# Altium schematic sheet Schematic.SchDoc(Schematic)
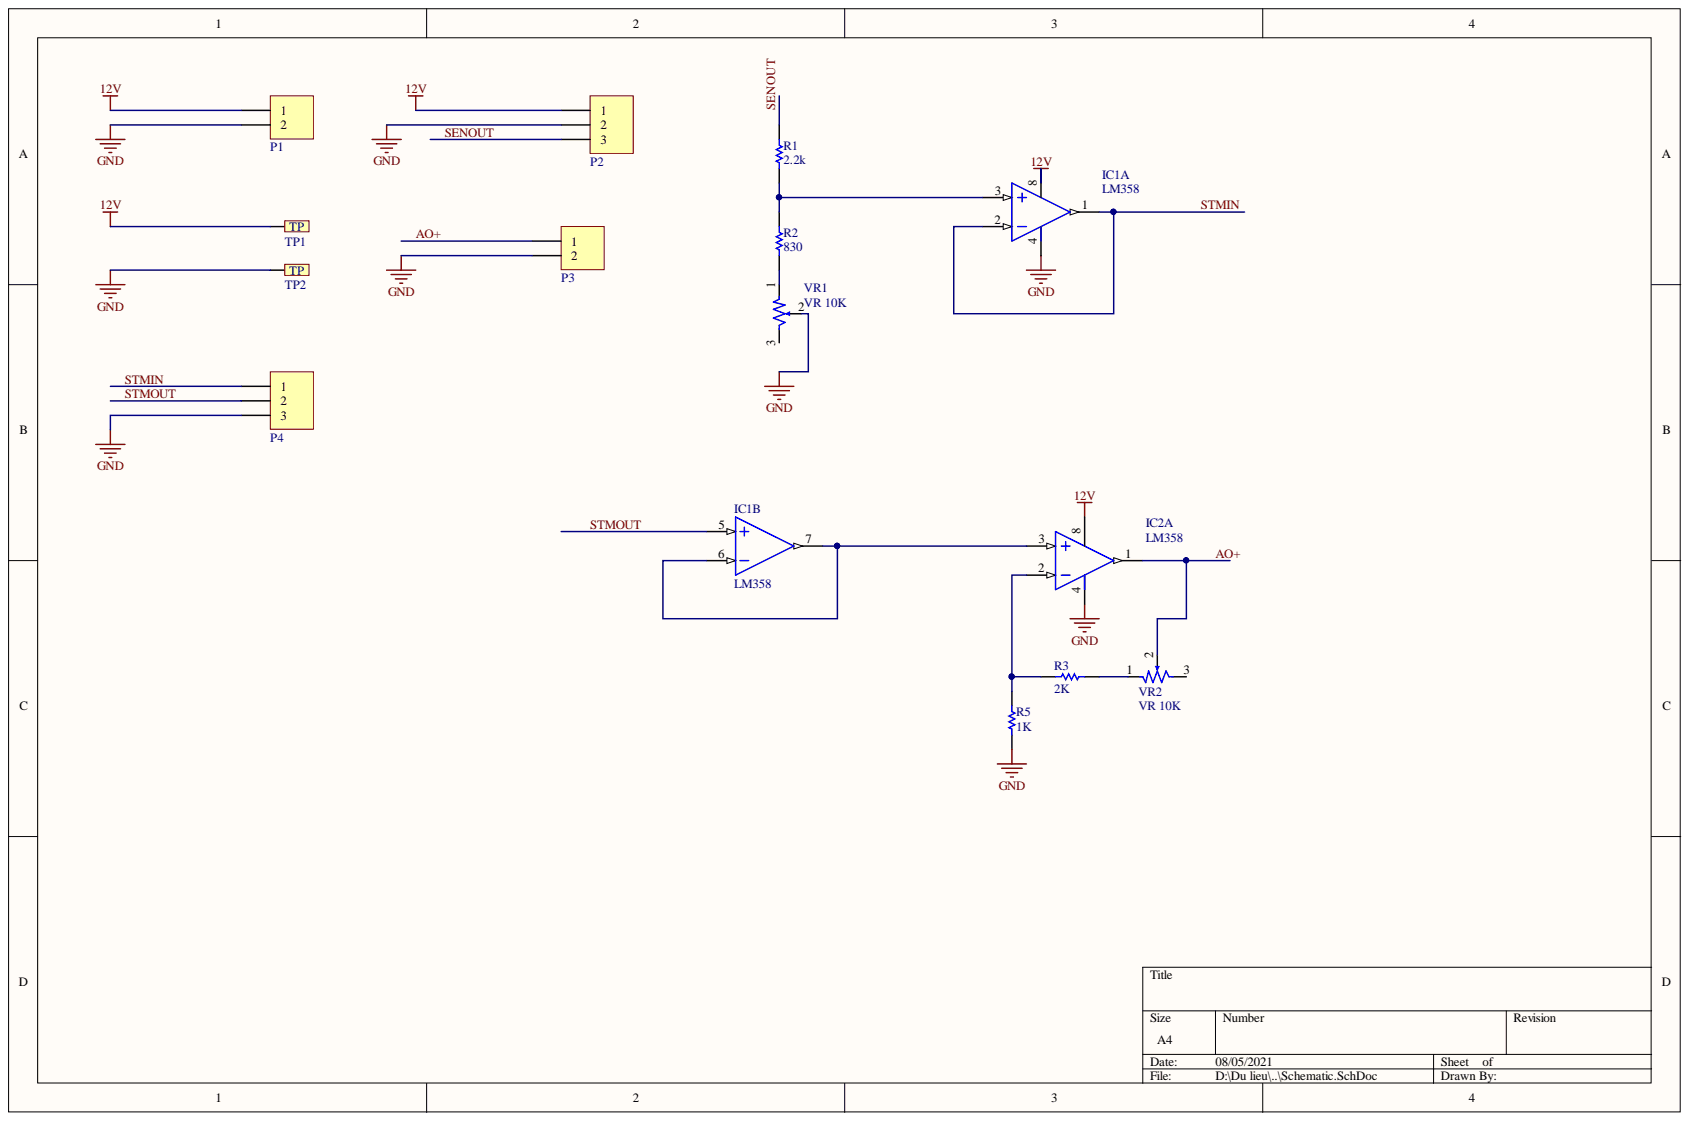
[diagram p0: Schematic.SchDoc]

## Parts
IC1  LM358  [DIP8]  (parts A,B)
IC2  LM358  [DIP8]  (part A)
P1  Header 2H  [JP2H]  (value not drawn)
P2  Header 3  [JP3]  (value not drawn)
P3  Header 2H  [JP2H]  (value not drawn)
P4  Header 3  [JP3]  (value not drawn)
R1  value 2.2k  [RES-SM-0805]  (hidden comment: RESISTOR SMD)
R2  value 830  [RES-SM-0805]  (hidden comment: RESISTOR SMD)
R3  value 2K  [RES-SM-0805]  (hidden comment: RESISTOR SMD)
R5  value 1K  [RES-SM-0805]  (hidden comment: RESISTOR SMD)
TP1  Test Point  [TP]  (value not drawn)
TP2  Test Point  [TP]  (value not drawn)
VR1  10K  value VR 10K  [Varistor 1]
VR2  10K  value VR 10K  [Varistor 1]

## Nets
12V: IC1.8 IC2.8 P1.1 P2.1 TP1.TP1
GND: IC1.4 IC2.4 P1.2 P2.2 P3.2 P4.3 R5.2 TP2.TP1 VR1.2
AO+: IC2.1 P3.1 VR2.2
SENOUT: P2.3 R1.2
STMIN: IC1.1 IC1.2 P4.1
STMOUT: IC1.5 P4.2
NetIC1_3: IC1.3 R1.1 R2.2
NetIC1_6: IC1.6 IC1.7 IC2.3
NetIC2_2: IC2.2 R3.2 R5.1
NetR2_1: R2.1 VR1.1
NetR3_1: R3.1 VR2.1

Not connected: VR1 3; VR2 3

## Library data of the parts
IC1 IC2 = LM358: Low-Power Dual Operational Amplifier; Value: LM358; Library Name: LIBRARY.IntLib; Component Kind: Standard
P1 P3 = Header 2H: Header, 2-Pin, Right Angle; Library Name: PIF_Library.IntLib; LatestRevisionNote: Re-released for DXP Platform.; LatestRevisionDate: 17-Jul-2002; Component Kind: Standard; Publisher: Altium Limited
P2 P4 = Header 3: Header, 3-Pin; Library Name: PIF_Library.IntLib; LatestRevisionNote: Re-released for DXP Platform.; LatestRevisionDate: 17-Jul-2002; Component Kind: Standard; Publisher: Altium Limited
R1-R3 R5 = RESISTOR SMD: Resistor; Library Name: PIF_Library.IntLib; Component Kind: Standard
VR1 VR2 = Var Resistor: Library Name: PIF_Library.IntLib; Description: Var Resistor; Component Kind: Standard
TP1 TP2 = Test Point: Library Name: PIF_Library.IntLib; Component Kind: Standard
